# Altium schematic sheet Power_Supply.SchDoc
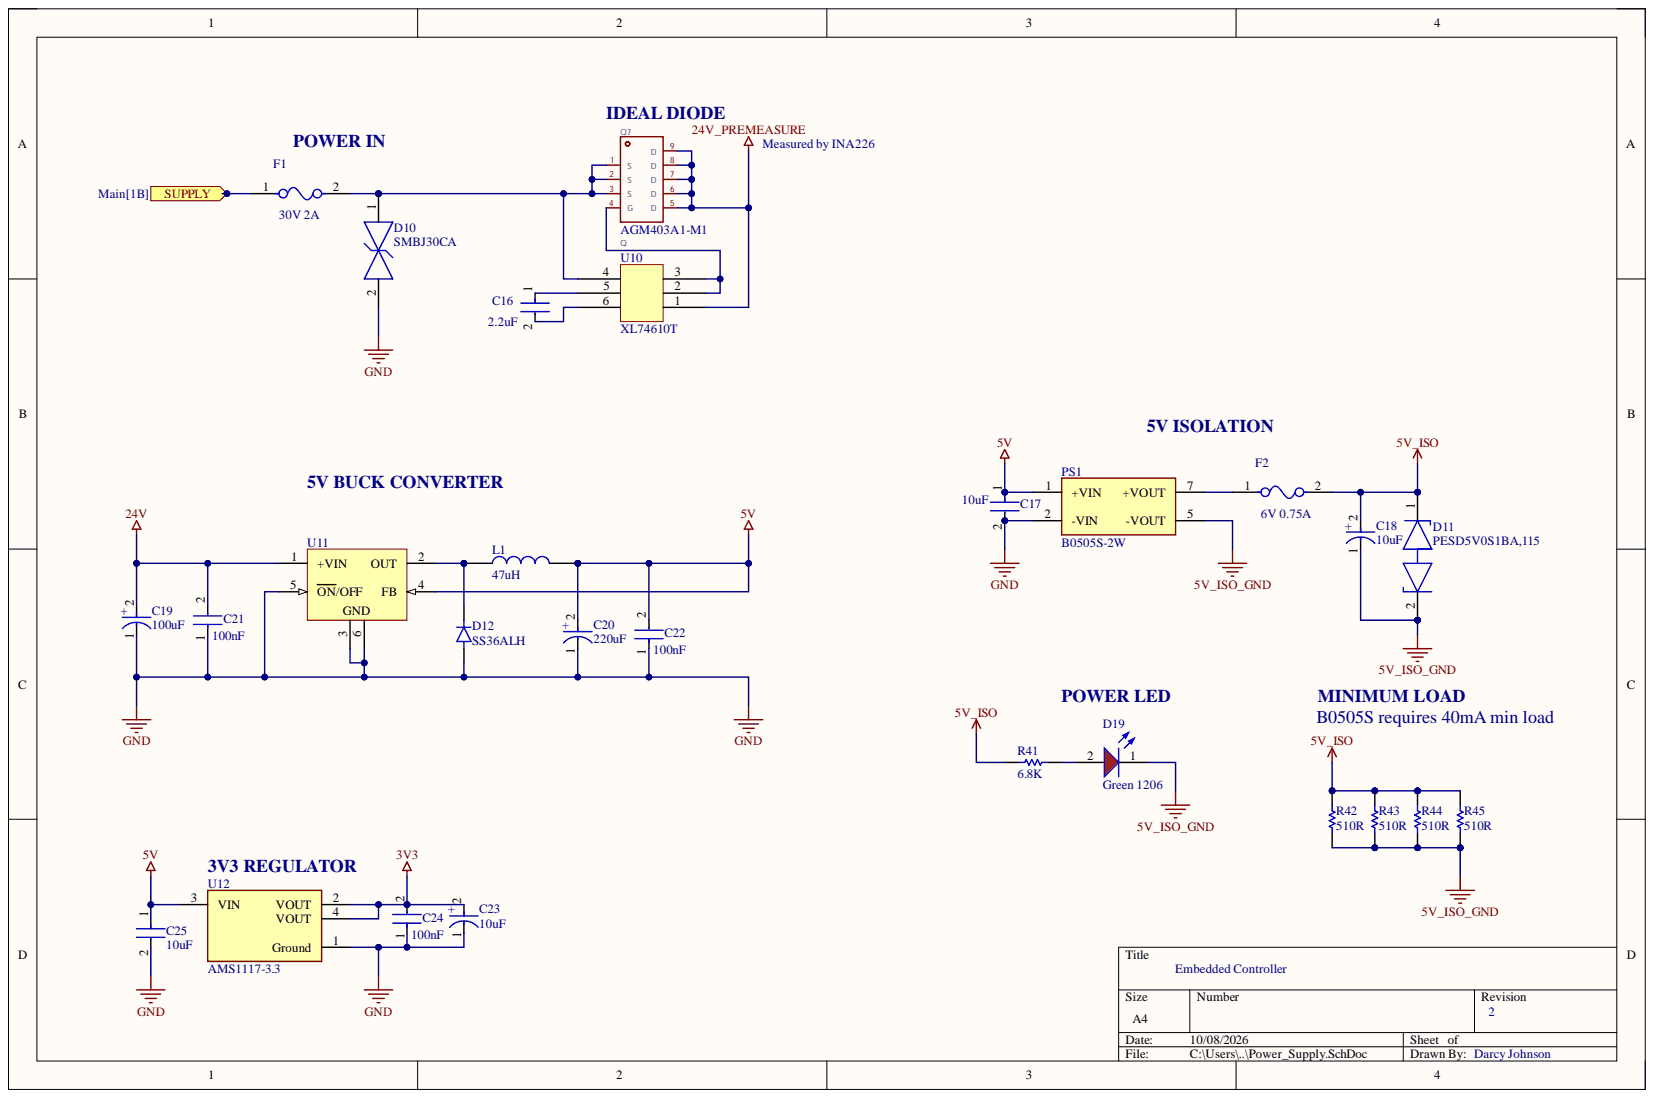
[diagram p0: Power_Supply.SchDoc]

## Parts
C16  2.2uF  [FP-GRM188-0_1-e0_2_0_5-MFG]
C17  10uF  [FP-C3216-160-0_2-IPC_A]
C18  10uF  [FP-FKB-MFG]
C19  100uF  [FP-FKF-MFG]
C20  220uF  [FP-FPF-MFG]
C21  100nF  [FP-1206-L_3_2_0_2-W_1_6_0-MFG]
C22  100nF  [FP-1206-L_3_2_0_2-W_1_6_0-MFG]
C23  10uF  [FP-FKB-MFG]
C24  100nF  [FP-1206-L_3_2_0_2-W_1_6_0-MFG]
C25  10uF  [FP-C3216-160-0_2-IPC_A]
D10  SMBJ30CA  [FP-SMBJ30CAHE3-52-MFG]
D11  PESD5V0S1BA,115  [FP-SOD323-CA-MFG]
D12  SS36ALH  [SODFL5226X100N]
D19  Green 1206  Case Code (Imperial): 1206  [WL-SMCW_1206_150120xx75000]
F1  30V 2A  Voltage Rating: 30V  [FP-MF-USMF-MFG]
F2  6V 0.75A  [FP-MF-USMF-MFG]
L1  47uH  [INDPM120120X800N]
PS1  B0505S-2W  [B0505S-2W]
Q7  AGM403A1-M1  [ProLib_pcs_2025-06-23:DFN-8_L5.8-W4.9-P1.27-LS6.0-BL-1]
R41  6.8K  [RESC1608X55X30LL15T15]
R42  510R  [RESC3216X70X50LL20T25]
R43  510R  [RESC3216X70X50LL20T25]
R44  510R  [RESC3216X70X50LL20T25]
R45  510R  [RESC3216X70X50LL20T25]
U10  XL74610T  [SOT95P245X110-6N]
U11  (no value)  [KTT0005B_L]
U12  AMS1117-3.3  [FP-AMS1117-IPC_C]

## Ports
SUPPLY

## Nets
3V3: C23.2 C24.2 U12.2(VOUT) U12.4(VOUT)
5V: C17.1 C20.2 C22.2 C25.1 L1.2 PS1.1(+VIN) U11.4(FB) U12.3(VIN)
5V_ISO: C18.2 D11.1 F2.2 R41.1 R42.1 R43.1 R44.1 R45.1
5V_ISO_GND: C18.1 D11.2 D19.1 PS1.5(-VOUT) R42.2 R43.2 R44.2 R45.2
24V: C19.2 C21.2 U11.1(+VIN)
24V_PREMEASURE: Q7.5(D) Q7.6(D) Q7.7(D) Q7.8(D) Q7.9(D) U10.1
GND: C17.2 C19.1 C20.1 C21.1 C22.1 C23.1 C24.1 C25.2 D10.2 D12.2 PS1.2(-VIN) U11.3 U11.5(ON/OFF) U11.6 U12.1(Ground)
SUPPLY: F1.1 ; port SUPPLY
NetC16_1: C16.1 U10.5
NetC16_2: C16.2 U10.6
NetD10_1: D10.1 F1.2 Q7.1(S) Q7.2(S) Q7.3(S) U10.4
NetD12_1: D12.1 L1.1 U11.2(OUT)
NetD19_2: D19.2 R41.2
NetF2_1: F2.1 PS1.7(+VOUT)
NetQ7_4: Q7.4(G) U10.2 U10.3

## Library data of the parts
C16 = CMP-2006-02836-2: Chip Multilayer Ceramic Capacitors for General Purpose, 0603, 2.2uF, X7R, 15%, 10%, 10V; Capacitance: 2.2uF; Case Code (Imperial): 0603; Case Code (Metric): 1608; Case/Package: 0603; Component Kind: Standard; Depth: 0.8mm; Dielectric: X7R; Height: 0.8mm; Lead Free: Lead Free; Length: 1.6mm; Library Name: Altium Content Vault; Material: Ceramic; Max Operating Temperature: 125°C; Min Operating Temperature: -55°C; Mount: Surface Mount; Number of Pins: 2; Package Quantity: 1; Packaging: Cut Tape; REACH SVHC: No SVHC; Termination: SMD/SMT; Thickness: 0.035inch; Tolerance: 10%; Voltage: 10V; Voltage Rating: 10V; Voltage Rating (DC): 10V; Weight: 7.1E-05oz; Width: 0.031inch
C17 C25 = CMP-2000-06045-2: Multilayer Ceramic Capacitors 10uF ±10% 50V X5R SMD 1206; Capacitance: 10uF; Case Code (Imperial): 1206; Case Code (Metric): 3216; Case/Package: 1206; Component Kind: Standard; Construction: Flat; Depth: 1.6mm; Dielectric: X5R; Dielectric Material: Ceramic; Height: 1.6mm; Lead Free: Lead Free; Length: 3.2mm; Library Name: Altium Content Vault; Max Operating Temperature: 85°C; Min Operating Temperature: -55°C; Mount: Surface Mount; Package Quantity: 1; Packaging: Tape and Reel; Radiation Hardening: No; REACH SVHC: No SVHC; Resistor Type: Ceramic; RoHS Compliant: Yes; Series: C; Termination: SMD/SMT; Thickness: 0.071inch; Tolerance: 10%; Voltage: 50V; Voltage Rating: 50V; Voltage Rating (DC): 50V; Weight: 0.000571oz; Width: 0.063inch
C18 C23 = CMP-2000-06211-2: CAP ALUM 10UF 20% 35V SMD; ESR (Equivalent Series Resistance): 1.35R; Leakage Current: 3uA; Life (Hours): 2000Hour; Material: Aluminium; Polarity: Polar; Ripple Current: 90mA; Capacitance: 10uF; Case/Package: Radial; Component Kind: Standard; Construction: Cylindrical; Contact Plating: Tin; Diameter: 4mm; Height: 5.8mm; Height - Seated (Max): 0.24inch; Impedance: 1.35R; Lead Free: Lead Free; Length: 5.8mm; Library Name: Altium Content Vault; Max Operating Temperature: 105°C; Min Operating Temperature: -55°C; Mount: Surface Mount; Number of Pins: 2; Package Quantity: 1; Packaging: Tape and Reel; Radiation Hardening: No; REACH SVHC: No SVHC; Ripple Current (AC): 90mA; RoHS Compliant: Yes; Series: FK; Termination: SMD/SMT; Tolerance: 20%; Voltage: 35V; Voltage Rating: 35V; Voltage Rating (DC): 35V; Width: 5.5mm
C19 = CMP-2000-06208-3: CAP ALUM 100UF 20% 50V SMD; Dielectric Material: Aluminium; ESR (Equivalent Series Resistance): 340mR; Leakage Current: 3uA; Life (Hours): 2000Hour; Ripple Current: 350mA; Capacitance: 100uF; Case/Package: Radial; Component Kind: Standard; Construction: Cylindrical; Contact Plating: Tin; Diameter: 8mm; Height: 10.2mm; Height - Seated (Max): 0.402inch; Impedance: 340mR; Lead Free: Lead Free; Length: 10.2mm; Library Name: Altium Content Vault; Material: Aluminium; Max Operating Temperature: 105°C; Min Operating Temperature: -55°C; Mount: Surface Mount; Number of Pins: 2; Package Quantity: 1; Packaging: Tape and Reel; Radiation Hardening: No; REACH SVHC: No SVHC; Ripple Current (AC): 350mA; RoHS Compliant: Yes; Series: FK; Termination: SMD/SMT; Tolerance: 20%; Voltage: 50V; Voltage Rating: 50V; Voltage Rating (DC): 50V; Width: 10mm
C20 = CMP-2000-06215-2: CAP ALUM 220UF 20% 35V SMD; ESR (Equivalent Series Resistance): 80mR; Leakage Current: 77uA; Life (Hours): 2000Hour; Material: Aluminium; Polarity: Polar; Ripple Current: 850mA; Capacitance: 220uF; Case/Package: Radial; Component Kind: Standard; Construction: Cylindrical; Contact Plating: Tin; Diameter: 8mm; Height: 10.2mm; Height - Seated (Max): 0.402inch; Lead Free: Lead Free; Lead Pitch: 3.1mm; Length: 10.5mm; Library Name: Altium Content Vault; Max Operating Temperature: 105°C; Min Operating Temperature: -55°C; Mount: Surface Mount; Number of Pins: 2; Package Quantity: 500; Packaging: Tape and Reel; Radiation Hardening: No; REACH SVHC: No SVHC; Ripple Current (AC): 850mA; RoHS Compliant: Yes; Series: FP; Termination: SMD/SMT; Tolerance: 20%; Voltage: 35V; Voltage Rating: 35V; Voltage Rating (DC): 35V; Width: 8.3mm
C21 C22 C24 = CMP-2000-05018-2: General Purpose Ceramic Capacitor, 1206, 100nF, 10%, X7R, 15%, 50V; Dissipation Factor: 2.5%; Insulation Resistance: 100GR; Capacitance: 100nF; Case Code (Imperial): 1206; Case Code (Metric): 3216; Case/Package: 1206; Component Kind: Standard; Construction: Flat; Contact Plating: Tin; Depth: 1.6mm; Dielectric: X7R; Dielectric Material: Ceramic; Height: 0.94mm; Lead Free: Lead Free; Length: 3.2mm; Library Name: Altium Content Vault; Max Operating Temperature: 125°C; Min Operating Temperature: -55°C; Mount: Surface Mount; Number of Pins: 2; Package Quantity: 1; Packaging: Tape and Reel; Radiation Hardening: No; REACH SVHC: No SVHC; RoHS Compliant: Yes; Series: -; Termination: SMD/SMT; Thickness: 0.037inch; Tolerance: 10%; Voltage: 50V; Voltage Rating: 50V; Voltage Rating (DC): 50V; Width: 1.6mm
D10 = CMP-02577-000018-1: TVS DIODE 30V 48.4V DO214AA; Manufacturer: Vishay Semiconductor Diodes Division; Manufacturer Part Number: SMBJ30CAHE3/52; Automotive Grade: Grade 0; Breakdown Voltage: 33.3V; Direction: Bidirectional; Max Junction Temp: +150°C; Number Of Channels: 1; Peak Pulse Current: 12.4A; Peak Pulse Power: 600W; Standoff Height: 0mm; Automotive: Yes; Category: Diode; Clamping Voltage: 48.4V; Component Kind: Standard; ComponentLink2Description: Datasheet; ComponentLink2URL: http://www.vishay.com/docs/88392/smbj.pdf; Device Class L1: Circuit Protection; Device Class L2: TVS Diodes; Device Class L3: unset; Digikey Description: TVS DIODE 30V 48.4V DO214AA; Footprint Url: http://www.vishay.com/docs/95401/smb.pdf; Height: 2.44mm; Lead Free: Yes; Library Name: Altium Content Vault; Mouser Description: Diode TVS Single Bi-Dir 30V 600W 2-Pin DO-214AA T/R; Octopart: https://octopart.com/smbj30cahe3%2F52-vishay-43725255; Package: DO-214AA; Reverse Standoff Voltage: 30V; RoHS: TRUE; Temperature: -55°C to +150°C; Temperature Range High: +150°C; Temperature Range Low: -55°C
D11 = CMP-14432-000001-1: TVS DIODE 5V 14V SOD323; Component Kind: Standard; Library Name: Altium Content Vault
D12 = SS36ALH: 3A, 60V, Schottky Rectifier; MF: Taiwan Semiconductor; Availability: Not in stock; Check_prices: https://www.snapeda.com/parts/SS36ALH/Taiwan+Semiconductor/view-part/?ref=eda; Component Kind: Standard; Library Name: SS36ALH.IntLib; MP: SS36ALH; Package: DO-221AC Taiwan Semiconductor; Price: None; SnapEDA_Link: https://www.snapeda.com/parts/SS36ALH/Taiwan+Semiconductor/view-part/?ref=snap
D19 = CMP-1426-00017-3: Led, Red, 630 Nm, 1.9 V, 30 Ma, 60 Mcd Rohs Compliant: Yes; Dominant Wavelength: 630nm; Forward Current: 100mA; Forward Voltage: 1.9V; Lens Style: Clear, Rectangular; Luminous Intensity: 60mcd; Max Reverse Voltage (DC): 5V; Peak Wavelength: 645nm; Test Current: 20mA; Viewing Angle: 140°; Case Code (Metric): 3216; Case/Package: 1206; Color: Red; Component Kind: Standard; Height: 680µm; Length: 3.2mm; Library Name: Altium Content Vault; Max Operating Temperature: 85°C; Min Operating Temperature: -40°C; Mount: Surface Mount; Packaging: Tape and Reel; REACH SVHC: Unknown; RoHS: Compliant; Wavelength: 630nm; Width: 1.6mm
F1 F2 = CMP-07400-000009-1: PTC RESET FUSE 30V 200MA 1210; Manufacturer: Bourns Inc.; Manufacturer Part Number: MF-USMF020-2; Automotive Grade: Grade 3; Current Rating: 10A; Hold Current: 0.2A; Resistance: 0.4-5Ω; Trip Current: 0.4A; Trip Time: 0.02s; Value: 0.4-5Ω; Automotive: Yes; Category: Fuse; Component Kind: Standard; ComponentLink2Description: Datasheet; ComponentLink2URL: https://www.bourns.com/docs/Product-Datasheets/mfusmf.pdf; Device Class L1: Circuit Protection; Device Class L2: PTC Resettable Fuses; Device Class L3: unset; Digikey Description: PTC RESET FUSE 30V 200MA 1210; Height: 1.1mm; Lead Free: Yes; Library Name: Altium Content Vault; Mouser Description: Resettable Fuses - PPTC 0.20A 30V 0.40ohm Hold .20A Trip .4A; Octopart: https://octopart.com/mf-usmf020-2-bourns-46429; Package: MF-USMF; RoHS: TRUE; Temperature: -40°C to +85°C; Temperature Range High: +85°C; Temperature Range Low: -40°C
  F2: Voltage Rating: 30V
L1 = SPD127R-473M: Fixed Inductors 47uH 20% .1ohm Shielded Choke SMT; Manufacturer_Name: API Delevan; Geometry.Height: 8mm; Component Kind: Standard; Datasheet Link: https://componentsearchengine.com/Datasheets/1/SPD127R-473M.pdf; Library Name: SPD127R-473M.SchLib; Manufacturer_Part_Number: SPD127R-473M; Mouser Part Number: 807-SPD127R-473M; Mouser Price/Stock: https://www.mouser.co.uk/ProductDetail/API-Delevan/SPD127R-473M?qs=Ri2cEtl8%2FQnrzzldCovvkw%3D%3D
PS1 = 2S7BE_0505S3U: Isolated Module DC DC Converter 1 Output 5V 400mA 4.5V - 5.5V Input; MF: Gaptec; Check_prices: https://www.snapeda.com/parts/2S7BE_0505S3U/Gaptec/view-part/?ref=eda; Component Kind: Standard; Library Name: 2S7BE_0505S3U.SchLib; MP: 2S7BE_0505S3U; Package: None; SnapEDA_Link: https://www.snapeda.com/parts/2S7BE_0505S3U/Gaptec/view-part/?ref=snap
Q7 = AGM403A1-M1: Type:1 N-channel Drain to Source Voltage: Current - Continuous Drain(Id): RDS(on):2.2mΩ@10V,20A Pd - Power Dissipation(Pd): Gate Threshold Voltage (Vgs(th)@Id):1.2V Gate Charge(Qg):65nC@10V Input Capacitance(Ciss@Vds):5341pF@15V Reverse Transfer Capacitan; Manufacturer: AGM-Semi(?控源); Reference: Q; Component Kind: Standard; Datasheet: https://atta.szlcsc.com/upload/public/pdf/source/20241030/BE071EF894F55F0B37AD6167FC56B3C6.pdf; Description_: Type:1 N-channel Drain to Source Voltage: Current - Continuous Drain(Id): RDS(on):2.2mΩ@10V,20A Pd - Power Dissipation(Pd): Gate Threshold Voltage (Vgs(th)@Id):1.2V Gate Charge(Qg):65nC@10V Input Capacitance(Ciss@Vds):5341pF@15V Reverse Transfer Capacitan; LCSC Part Name: AGM403A1-M1; Library Name: agm403a1-m1.SchLib; Manufacturer Part: AGM403A1-M1; Supplier: LCSC; Supplier Part: C42372665
R41 = CMP-2000-00803-1: Manufacturer: Panasonic; Part Number: ERJ-3GEYJ512V; Component Kind: Standard; Library Name: Altium Content Vault
R42-R45 = CMP-2003-04407-1: Manufacturer: Panasonic; Part Number: ERA8AEB103V; Component Kind: Standard; Library Name: Altium Content Vault
U11 = CMP-2000-06545-1: Simple Switcher(R) Power Converter 150 kHz Step-Down Voltage Regulator, 3 A, 4.5 to 40 V, -40 to 125 degC, 5-Pin TO-263 (KTT), Green (RoHS & no Sb/Br), Tape and Reel; Manufacturer: Texas Instruments; PartNumber: LM2596; No. of Outputs: 1; No. of Pins: 5; Output Current: 3A; Output Voltage: 37V; Package Version: 4200577-4/G, 01/2013; Primary Input Voltage: 40V; SVHC: NoSVHC(19-Dec-2012); Component Kind: Standard; Datasheet URL: http://www.ti.com/lit/ds/symlink/lm2596.pdf; Datasheet Version: Rev. C, 04/2013; Library Name: LM2596.SchLib; Manufacturer URL: http://www.ti.com/; Mounting Technology: SurfaceMount; MSL: MSL3-168hours; Number of Outputs: 1; Operating Temperature Max: 125°C; Operating Temperature Min: -40°C; Operating Temperature Range: -40°Cto+125°C; Package Description: 5-Pin Plastic Flange Mount, Body 10.16 x 8.925 mm, Pitch 1.7 mm; Package Reference: KTT5; Package URL: http://www.ti.com/litv/pdf/mpsf007e; Voltage Regulator Case Style: TO-263
U12 = CMP-209535-000001-1: LDO Voltage Regulators 1A, 3.3V; Manufacturer: Advanced Monolithic Systems; Dropout Voltage: 1.1V; Max Junction Temp: +125°C; Max Power Dissipation: 1.2W; Max Supply Voltage: 15V; Nominal Supply Current: 5mA; Number Of Outputs: 1; Output Type: Fixed; Standoff Height: 0.64mm; Automotive: No; Category: IC; Component Kind: Standard; ComponentLink2Description: Datasheet; ComponentLink2URL: http://www.advanced-monolithic.com/pdf/ds1117.pdf; Device Class L1: Integrated Circuits (ICs); Device Class L2: Power Management ICs; Device Class L3: Voltage Regulators - Linear; Height: 1.8mm; Ipc Land Pattern Name: SOT229P700X180-4; Lead Free: Yes; Library Name: Altium Content Vault; Manufacturer Part Number: AMS1117-3.3; Octopart: https://octopart.com/ams1117-3.3-advanced+monolithic+systems-25899300; Output Current: 1A; Output Voltage: 3.3V; Package: AMS1117; RoHS: TRUE; Temperature: -40°C to +125°C; Temperature Range High: +125°C; Temperature Range Low: -40°C
U10 = XL74610T: Component Kind: Standard; Library Name: XL74610T.SchLib
